# Altium schematic sheet RefVoltages.SchDoc
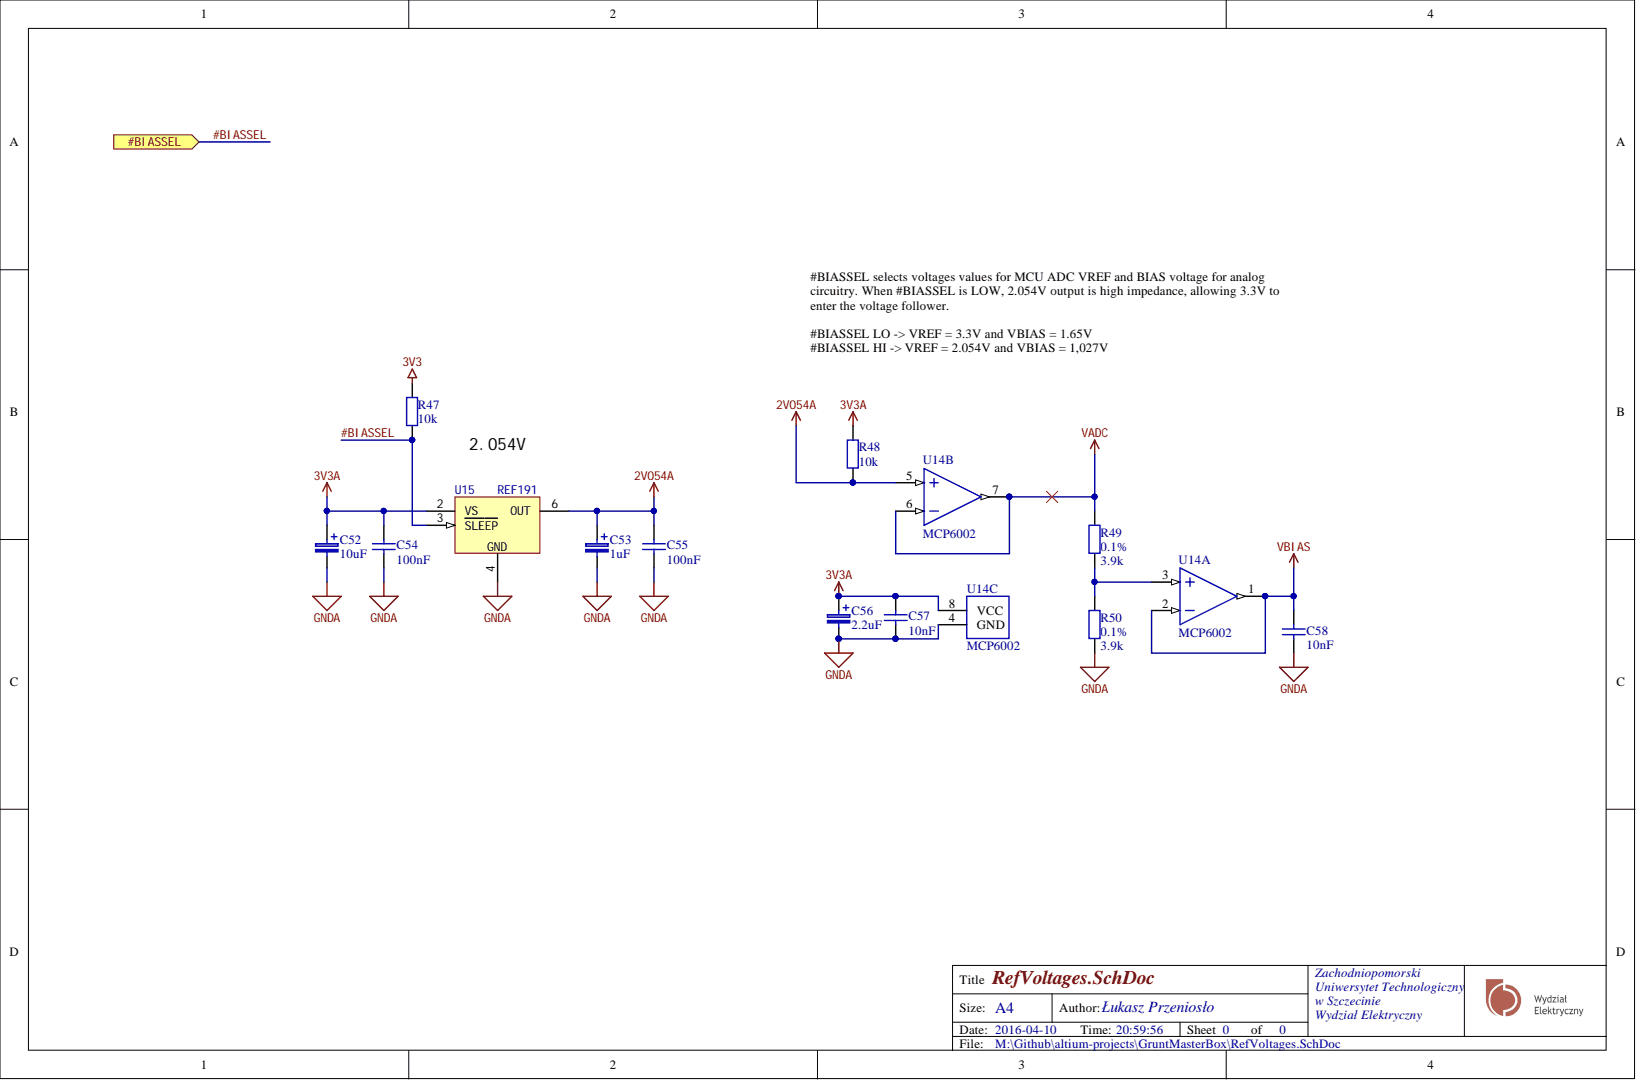
[diagram p0: RefVoltages.SchDoc]

## Parts
C52  10uF  [SMDA]
C53  1uF  [SMDA]
C54  100nF  [CAPC1608N]
C55  100nF  [CAPC1608N]
C56  2.2uF  [SMDA]
C57  10nF  [CAPC1608N]
C58  10nF  [CAPC1608N]
R47  10k  [RESC1608N]
R48  10k  [RESC1608N]
R49  3.9k  Tolerance: 0.1%  [RESC1608N]
R50  3.9k  Tolerance: 0.1%  [RESC1608N]
U14  MCP6002  [SO-8_L]  (parts A,B,C)
U15  REF191  [SO-8_L]

## Ports
#BIASSEL

## Nets
2V054A: C53.1 C55.1 R48.1 U14.5 U15.6(OUT)
3V3: R47.2
3V3A: C52.1 C54.1 C56.1 C57.1 R48.2 U14.8(VCC) U15.2(VS)
GNDA: C52.2 C53.2 C54.2 C55.2 C56.2 C57.2 C58.2 R50.2 U14.4(GND) U15.4
VADC: R49.1 U14.6 U14.7
VBIAS: C58.1 U14.1 U14.2
#BIASSEL: R47.1 U15.3(SLEEP) ; port #BIASSEL
NetR49_2: R49.2 R50.1 U14.3

## Library data of the parts
C52 = 100uF 6.3V-SMDB: Polarized Capacitor (Surface Mount); Component Kind: Standard; Distributor: tme.pl; Distributor Order Code: TC 100/6.3-SMDB; farnell: 1135048; PackageReference: SMDB; Signal Integrity: Cap Pol; Simulation: CAP; tme.pl: TC 100/6.3-SMDB; Value: 10uF; Library Name: Mechatronic.SchLib
C53 C56 = 100uF 6.3V-SMDB: Polarized Capacitor (Surface Mount); Value: 10uF; Component Kind: Standard; Distributor: tme.pl; Distributor Order Code: TC 100/6.3-SMDB; farnell: 1135048; PackageReference: SMDB; Signal Integrity: Cap Pol; Simulation: CAP; tme.pl: TC 100/6.3-SMDB; Library Name: Mechatronic.SchLib
C54 C55 C57 C58 = Capacitor: Component Kind: Standard; Description: Capacitor; Library Name: Discrete.SchLib; Signal Integrity: Cap; Simulation: CAP
  C54 C55: Value: 100nF
  C57 C58: Value: 10nF
R47 R48 = Resistor: Power: 0W; Tolerance: 5%; Component Kind: Standard; Description: Resistor; Library Name: Discrete.SchLib; Signal Integrity: Res; Simulation: RESISTOR; Value: 10k
R49 R50 = Resistor: Power: 0W; Component Kind: Standard; Description: Resistor; Library Name: Discrete.SchLib; Signal Integrity: Res; Simulation: RESISTOR; Value: 3.9k
U14 = MCP6002: Dual op-amp; Component Kind: Standard; Library Name: my_various_devices.SchLib
U15 = REF191: REF191 2.048V; Component Kind: Standard; Library Name: my_various_devices.SchLib
